# Altium schematic sheet 03_Power_IO.SchDoc
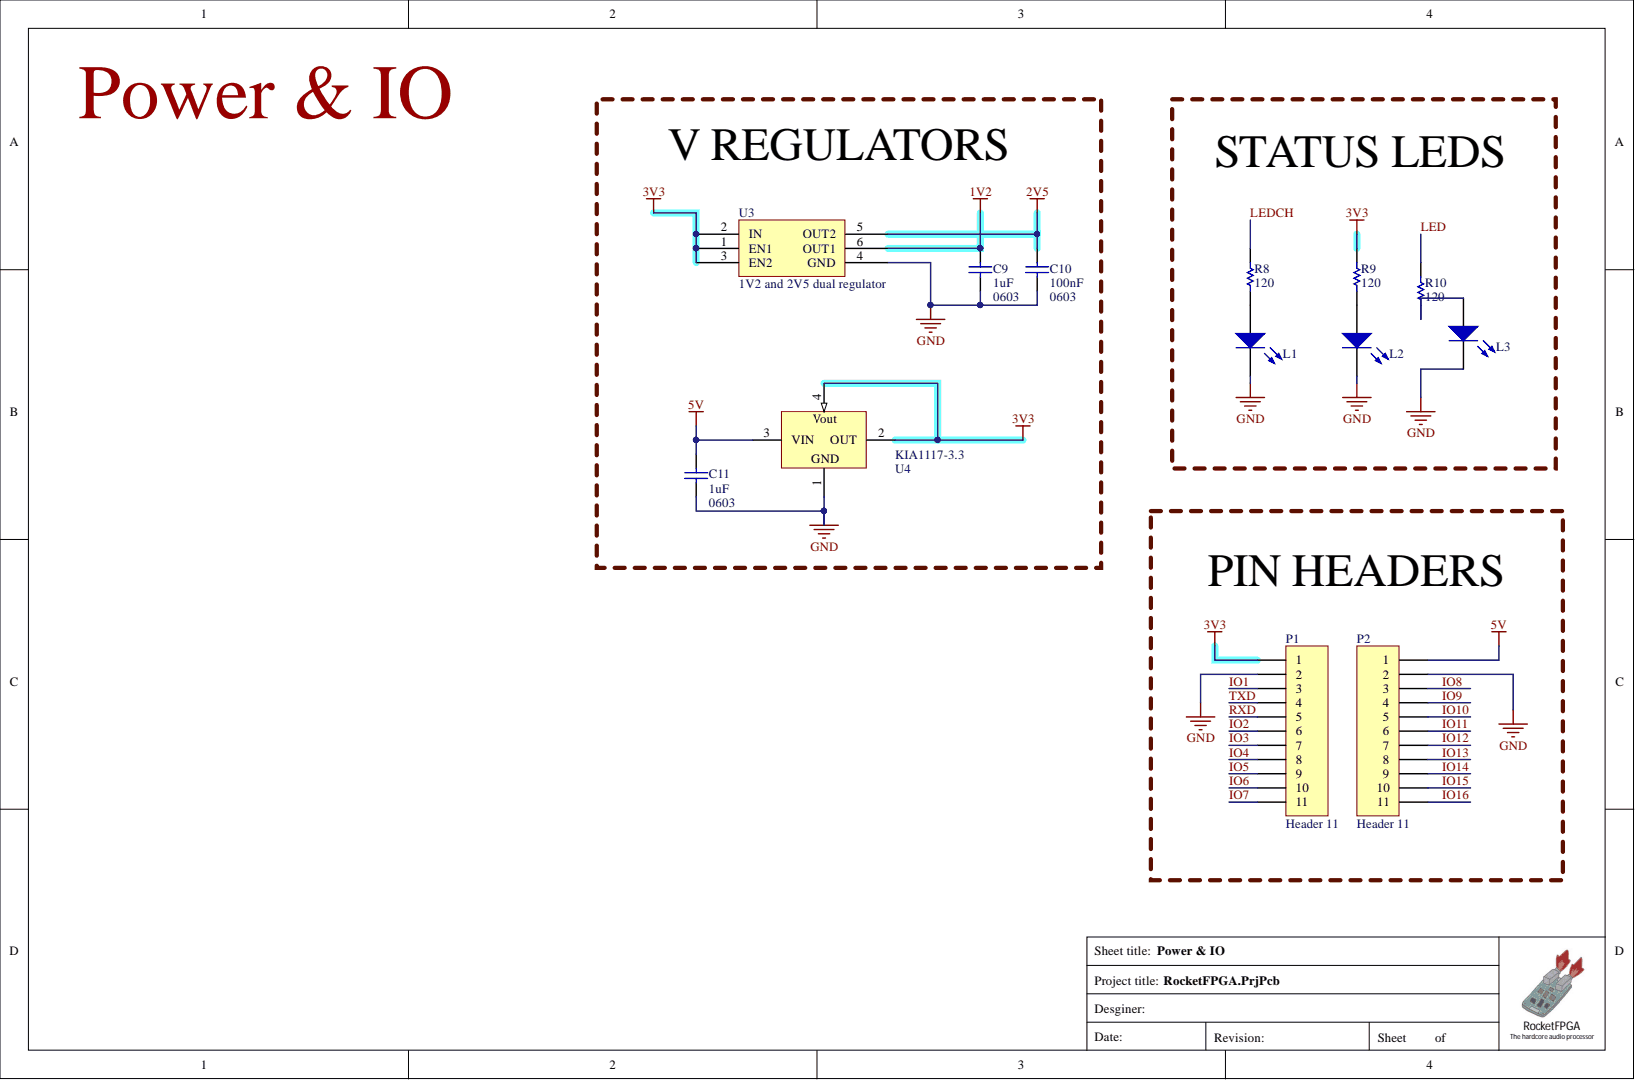
[diagram p0: 03_Power_IO.SchDoc]

## Parts
C9  value 1uF  Package: 0603  [0603 CAP]  (hidden comment: Ceramic capacitor)
C10  value 100nF  Package: 0603  [0603 CAP]  (hidden comment: Ceramic capacitor)
C11  value 1uF  Package: 0603  [0603 CAP]  (hidden comment: Ceramic capacitor)
L1  LED_SMD  [0805]  (value not drawn)
L2  LED_SMD  [0805]  (value not drawn)
L3  LED_SMD  [0805]  (value not drawn)
P1  Header 11  [HDR1X11]
P2  Header 11  [HDR1X11]
R8  value 120  [Resistencia 0603]  (hidden comment: Resistor)
R9  value 120  [Resistencia 0603]  (hidden comment: Resistor)
R10  value 120  [Resistencia 0603]  (hidden comment: Resistor)
U3  1V2 and 2V5 dual regulator  [DSE 6]
U4  KIA1117-3.3  [SOT-223]

## Nets
1V2: C9.1 U3.6(OUT1)
2V5: C10.1 U3.5(OUT2)
3V3: P1.1 R9.2 U3.1(EN1) U3.2(IN) U3.3(EN2) U4.2(OUT) U4.4
5V: C11.1 P2.1 U4.3(VIN)
GND: C9.2 C10.2 C11.2 L1.2 L2.2 L3.2 P1.2 P2.2 U3.4(GND) U4.1
IO1: P1.3
IO2: P1.6
IO3: P1.7
IO4: P1.8
IO5: P1.9
IO6: P1.10
IO7: P1.11
IO8: P2.3
IO9: P2.4
IO10: P2.5
IO11: P2.6
IO12: P2.7
IO13: P2.8
IO14: P2.9
IO15: P2.10
IO16: P2.11
LED: R10.2
LEDCH: R8.2
RXD: P1.5
TXD: P1.4
NetL1_1: L1.1 R8.1
NetL2_1: L2.1 R9.1
NetL3_1: L3.1 R10.1

## Library data of the parts
C9 C11 = Capacitor: vendor: LCSC; vendorID: CC0603ZRY5V7BB105; Component Kind: Standard; Description: Capacitor; Library Name: NodeFPGA.SCHLIB; ManufacturerReference: -; price: 0.0053; Signal Integrity: Cap; Simulation: CAP; Supplier 1: Mouser; Supplier Part Number 1: 80-C1206C104K5R
C10 = Capacitor: vendor: LCSC; vendorID: 0603F104M500NT; Component Kind: Standard; Description: Capacitor; Library Name: NodeFPGA.SCHLIB; ManufacturerReference: -; price: 0.0023; Signal Integrity: Cap; Simulation: CAP; Supplier 1: Mouser; Supplier Part Number 1: 80-C1206C104K5R
L1-L3 = LED_SMD: LED SMD; vendor: Mouser; vendorID: 743-IN-S85AT5B; Component Kind: Standard; Library Name: NodeFPGA.SCHLIB; price: 0.087; Supplier 1: Mouser; Supplier Part Number 1: 630-HSMC-C170
P1 P2 = Header 11_1: Header, 11-Pin; vendor: LCSC; vendorID: A2541WV-11P; Component Kind: Standard; LatestRevisionDate: 17-Jul-2002; LatestRevisionNote: Re-released for DXP Platform.; Library Name: NodeFPGA.SCHLIB; price: 0.18; Signal Integrity: Connector; Publisher: Altium Limited
R8-R10 = Resistor: vendor: LCSC; vendor2: vendor; vendorID: CR0603FA1200G; Component Kind: Standard; Description: Resistor; Library Name: OpenFPGA.SCHLIB; price: 0.0024; Signal Integrity: Res; Simulation: RESISTOR; Supplier 1: Farnell; Supplier Part Number 1: 2358436
U3 = TLV7111225DSER: vendor: Mouser; vendorID: 595-TLV7111225DSER; Component Kind: Standard; Library Name: NodeFPGA.SCHLIB; ManufacturerReference: TLV7111; Package: WSON 6; price: 0.489; Supplier 1: Arrow; Supplier Part Number 1: TLV7111225DSER**MULT1
U4 = KIA1117-3.3: 5V to 3.3V 800mA Low-Dropout Linear Regulator; vendor: LCSC; vendorID: C116069; Component Kind: Standard; Library Name: NodeFPGA.SCHLIB; ManufacturerReference: KIA1117-3.3; package: SOT-223; price: 0.05
